# Altium schematic sheet Supply.SchDoc
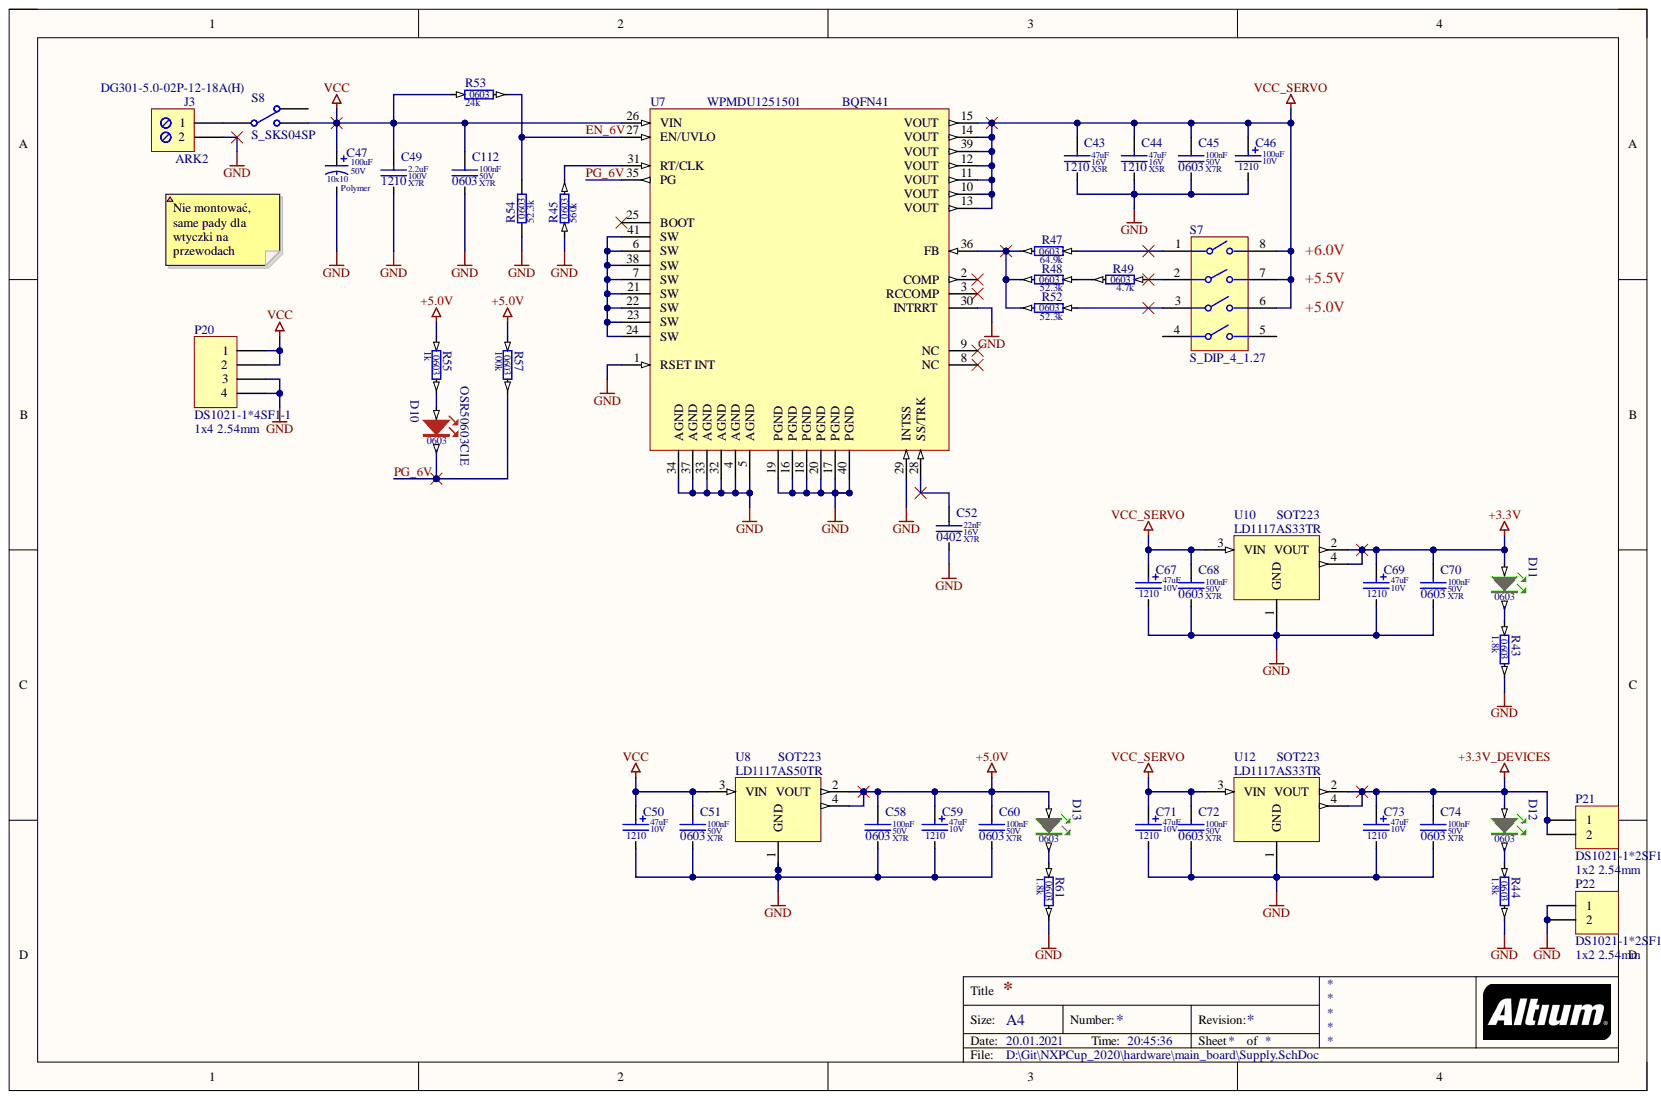
[diagram p0: Supply.SchDoc]

## Parts
C43  value 47uF  Dielectric: X5R  SCH_Footprint: 1210  Voltage: 16V  [C_1210_3225]  (hidden comment: Ceramic X5R)
C44  value 47uF  Dielectric: X5R  SCH_Footprint: 1210  Voltage: 16V  [C_1210_3225]  (hidden comment: Ceramic X5R)
C45  value 100nF  Dielectric: X7R  SCH_Footprint: 0603  Voltage: 50V  [C_0603_1608]  (hidden comment: Ceramic X7R)
C46  value 100uF  SCH_Footprint: 1210  Voltage: 10V  [CT_1210_3225]  (hidden comment: Tantalum Capacitor)
C47  value 100uF  Dielectric: Polymer  SCH_Footprint: 10x10  Voltage: 50V  [CE_D_10x10MM]  (hidden comment: Electrolytic Capacitor)
C49  value 2.2uF  Dielectric: X7R  SCH_Footprint: 1210  Voltage: 100V  [C_1210_3225]  (hidden comment: Ceramic X7R)
C50  value 47uF  SCH_Footprint: 1210  Voltage: 10V  [CT_1210_3225]  (hidden comment: Tantalum Capacitor)
C51  value 100nF  Dielectric: X7R  SCH_Footprint: 0603  Voltage: 50V  [C_0603_1608]  (hidden comment: Ceramic X7R)
C52  value 22nF  Dielectric: X7R  SCH_Footprint: 0402  Voltage: 16V  [C_0402_1005]  (hidden comment: Ceramic X7R)
C58  value 100nF  Dielectric: X7R  SCH_Footprint: 0603  Voltage: 50V  [C_0603_1608]  (hidden comment: Ceramic X7R)
C59  value 47uF  SCH_Footprint: 1210  Voltage: 10V  [CT_1210_3225]  (hidden comment: Tantalum Capacitor)
C60  value 100nF  Dielectric: X7R  SCH_Footprint: 0603  Voltage: 50V  [C_0603_1608]  (hidden comment: Ceramic X7R)
C67  value 47uF  SCH_Footprint: 1210  Voltage: 10V  [CT_1210_3225]  (hidden comment: Tantalum Capacitor)
C68  value 100nF  Dielectric: X7R  SCH_Footprint: 0603  Voltage: 50V  [C_0603_1608]  (hidden comment: Ceramic X7R)
C69  value 47uF  SCH_Footprint: 1210  Voltage: 10V  [CT_1210_3225]  (hidden comment: Tantalum Capacitor)
C70  value 100nF  Dielectric: X7R  SCH_Footprint: 0603  Voltage: 50V  [C_0603_1608]  (hidden comment: Ceramic X7R)
C71  value 47uF  SCH_Footprint: 1210  Voltage: 10V  [CT_1210_3225]  (hidden comment: Tantalum Capacitor)
C72  value 100nF  Dielectric: X7R  SCH_Footprint: 0603  Voltage: 50V  [C_0603_1608]  (hidden comment: Ceramic X7R)
C73  value 47uF  SCH_Footprint: 1210  Voltage: 10V  [CT_1210_3225]  (hidden comment: Tantalum Capacitor)
C74  value 100nF  Dielectric: X7R  SCH_Footprint: 0603  Voltage: 50V  [C_0603_1608]  (hidden comment: Ceramic X7R)
C112  value 100nF  Dielectric: X7R  SCH_Footprint: 0603  Voltage: 50V  [C_0603_1608]  (hidden comment: Ceramic X7R)
D10  value OSR50603C1E  Manufacturer Part Number: OSR50603C1E  SCH_Footprint: 0603  [OL_0603_1608]  (hidden comment: Red led)
D11  SCH_Footprint: 0603  [OL_0603_1608]  (hidden comment: OL_G)
D12  SCH_Footprint: 0603  [OL_0603_1608]  (hidden comment: OL_G)
D13  SCH_Footprint: 0603  [OL_0603_1608]  (hidden comment: OL_G)
J3  ARK2  value DG301-5.0-02P-12-18A(H)  Manufacturer Part Number: DG301-5.0-02P-12-18A(H)  [J_ARK2]
P20  value DS1021-1*4SF1-1  Manufacturer Part Number: DS1021-1*4SF1-1  SCH_Footprint: 1x4 2.54mm  [P_HEADER_1x4_2.54]  (hidden comment: Goldpin)
P21  Goldpin  [P_HEADER_1x2_2.54]  (value not drawn)
P22  SCH_Footprint: 1x2 2.54mm  [P_HEADER_1x2_2.54]  (hidden comment: Goldpin)
R43  value 1.8k  SCH_Footprint: 0603  [R_0603_1608]  (hidden comment: Resistor)
R44  value 1.8k  SCH_Footprint: 0603  [R_0603_1608]  (hidden comment: Resistor)
R45  value 560k  SCH_Footprint: 0603  [R_0603_1608]  (hidden comment: Resistor)
R47  value 64.9k  SCH_Footprint: 0603  [R_0603_1608]  (hidden comment: Resistor)
R48  value 52.3k  SCH_Footprint: 0603  [R_0603_1608]  (hidden comment: Resistor)
R49  value 4.7k  SCH_Footprint: 0603  [R_0603_1608]  (hidden comment: Resistor)
R52  value 52.3k  SCH_Footprint: 0603  [R_0603_1608]  (hidden comment: Resistor)
R53  value 24k  SCH_Footprint: 0603  [R_0603_1608]  (hidden comment: Resistor)
R54  value 52.3k  SCH_Footprint: 0603  [R_0603_1608]  (hidden comment: Resistor)
R55  value 1k  SCH_Footprint: 0603  [R_0603_1608]  (hidden comment: Resistor)
R57  value 100k  SCH_Footprint: 0603  [R_0603_1608]  (hidden comment: Resistor)
R61  value 1.8k  SCH_Footprint: 0603  [R_0603_1608]  (hidden comment: Resistor)
S7  DIP SWITCH 4 POS  [S_DIP_4_1.27]  (value not drawn)
S8  S_SKS04SP  [Switch_toggle]
U7  WPMDU1251501  SCH_Footprint: BQFN41  [BQFN41_11x9]
U8  LD1117AS50TR  SCH_Footprint: SOT223  [SOT223_SC73]
U10  LD1117AS33TR  SCH_Footprint: SOT223  [SOT223_SC73]
U12  LD1117AS33TR  SCH_Footprint: SOT223  [SOT223_SC73]

## Nets
+3.3V: C69.1 C70.1 D11.2 U10.2(VOUT) U10.4
+3.3V_DEVICES: C73.1 C74.1 D12.2 P21.1 P21.2 U12.2(VOUT) U12.4
+5.0V: C58.1 C59.1 C60.1 D13.2 R55.1 R57.1 U8.2(VOUT) U8.4
GND: C43.2 C44.2 C45.2 C46.2 C47.2 C49.2 C50.2 C51.2 C52.2 C58.2 C59.2 C60.2 C67.2 C68.2 C69.2 C70.2 C71.2 C72.2 C73.2 C74.2 C112.2 J3.2 P20.3 P20.4 P22.1 P22.2 R43.2 R44.2 R45.1 R54.1 R61.2 U7.1(RSET_INT) U7.4(AGND) U7.5(AGND) U7.16(PGND) U7.17(PGND) U7.18(PGND) U7.19(PGND) U7.20(PGND) U7.29(INTSS) U7.30(INTRRT) U7.32(AGND) U7.33(AGND) U7.34(AGND) U7.37(AGND) U7.40(PGND) U8.1(GND) U10.1(GND) U12.1(GND)
VCC: C47.1 C49.1 C50.1 C51.1 C112.1 P20.1 P20.2 R53.1 S8.3 U7.26(VIN) U8.3(VIN)
VCC_SERVO: C43.1 C44.1 C45.1 C46.1 C67.1 C68.1 C71.1 C72.1 S7.6 S7.7 S7.8 U7.10(VOUT) U7.11(VOUT) U7.12(VOUT) U7.13(VOUT) U7.14(VOUT) U7.15(VOUT) U7.39(VOUT) U10.3(VIN) U12.3(VIN)
EN_6V: R53.2 R54.2 U7.27(EN/UVLO)
PG_6V: D10.1 R57.2 U7.35(PG)
NetC52_1: C52.1 U7.28(SS/TRK)
NetD10_2: D10.2 R55.2
NetD11_1: D11.1 R43.1
NetD12_1: D12.1 R44.1
NetD13_1: D13.1 R61.1
NetJ3_1: J3.1 S8.2
NetR45_2: R45.2 U7.31(RT/CLK)
NetR47_1: R47.1 S7.1
NetR47_2: R47.2 R48.2 R52.2 U7.36(FB)
NetR48_1: R48.1 R49.2
NetR49_1: R49.1 S7.2
NetR52_1: R52.1 S7.3
NetU7_6: U7.6(SW) U7.7(SW) U7.21(SW) U7.22(SW) U7.23(SW) U7.24(SW) U7.38(SW) U7.41(SW)

Not connected: S7 4 5; S8 1; U7 2(COMP) 3(RCCOMP) 8(NC) 9(NC) 25(BOOT)

## Library data of the parts
C43 C44 = C_47uF_16V_X5R_1210_10: Capacitor; Manufacturer: Samsung; Manufacturer Part Number: CL32A476KOJNNNE; Database Table Name: Capacitors; Tolerance (%): 10; Component Kind: Standard; HelpURL: http://www.samsungsem.com/kr/support/product-search/mlcc/__icsFiles/afieldfile/2018/06/21/CL32A476KOJNNNE.pdf; Library Name: Library.DBLib; Part Number: C_47uF_16V_X5R_1210_10; Supplier 1: TME; Supplier 2: RS Components; Supplier 3: Farnell; Supplier 4: Mouser; Supplier 5: Digi-Key; Supplier Part Number 5: 1276-3376-1-ND
C45 C51 C58 C60 C68 C70 C72 C74 C112 = C_100nF_50V_X7R_0603_5: Capacitor; Manufacturer: Samsung; Manufacturer Part Number: CL10B104JB8NNNC; Database Table Name: Capacitors; Tolerance (%): 5; Component Kind: Standard; HelpURL: http://www.tme.eu/pl/Document/4a42202b32dab16128fe107dd69598cc/samsung-chip-cap.pdf; Library Name: Library.DBLib; Part Number: C_100nF_50V_X7R_0603_5; Supplier 1: TME; Supplier 2: RS Components; Supplier 3: Farnell; Supplier 4: Mouser; Supplier 5: Digi-Key; Supplier Part Number 1: CL10B104JB8NNNC
C46 C50 C59 C67 C69 C71 C73 = CT_100uF_10V_TA2O5_1210_20: Capacitor; Manufacturer: AVX; Manufacturer Part Number: TAJB107M010RNJ; Database Table Name: Capacitors; Dielectric: TA2O5; Tolerance (%): 20; Component Kind: Standard; HelpURL: https://datasheet.octopart.com/TAJB107M010RNJ-AVX-datasheet-12511273.pdf; Library Name: Library.DBLib; Part Number: CT_100uF_10V_TA2O5_1210_20; Supplier 1: TME; Supplier 2: RS Components; Supplier 3: Farnell; Supplier 4: Mouser; Supplier 5: Digi-Key; Supplier Part Number 1: TAJB107M010R; Supplier Part Number 2: 1360118; Supplier Part Number 3: 1658150; Supplier Part Number 4: 581-TAJB107M010R; Supplier Part Number 5: 478-5801-1-ND
C47 = CE_100uF_50V_Polymer_10x10_20: Polarized Capacitor; Manufacturer: Nichicon; Manufacturer Part Number: UUX1H101MNL1GS; Database Table Name: Capacitors; Tolerance (%): 20; Component Kind: Standard; HelpURL: https://datasheet.octopart.com/UUX1H101MNL1GS-Nichicon-datasheet-62047998.pdf; Library Name: Library.DBLib; Part Number: CE_100uF_50V_Polymer_10x10_20; Supplier 1: TME; Supplier 2: RS Components; Supplier 3: Farnell; Supplier 4: Mouser; Supplier 5: Digi-Key; Supplier Part Number 1: UUX1H101MNL1GS; Supplier Part Number 2: 739-3107; Supplier Part Number 3: 2841943; Supplier Part Number 4: 647-UUX1H101MNL1GS; Supplier Part Number 5: 493-6269-1-ND
C49 = C_2.2uF_100V_X7R_1210_10: Capacitor; Manufacturer: Samsung; Manufacturer Part Number: CL32B225KCJSNNE; Database Table Name: Capacitors; Tolerance (%): 10; Component Kind: Standard; HelpURL: http://datasheet.octopart.com/CL32B225KCJSNNE-Samsung-datasheet-37081328.pdf; Library Name: Library.DBLib; Part Number: C_2.2uF_100V_X7R_1210_10; Supplier 1: TME; Supplier 2: RS Components; Supplier 3: Farnell; Supplier 4: Mouser; Supplier 5: Digi-Key; Supplier Part Number 1: CL32B225KCJSNNE; Supplier Part Number 2: 7661211P; Supplier Part Number 5: 1276-3362-1-ND
C52 = C_22nF_16V_X7R_0402_10: Capacitor; Manufacturer: AVX; Manufacturer Part Number: 0402YC223KAT2A; Database Table Name: Capacitors; Tolerance (%): 10; Component Kind: Standard; HelpURL: http://datasheet.octopart.com/0402YC223KAT2A-AVX-datasheet-78690206.pdf; Library Name: Library.DBLib; Part Number: C_22nF_16V_X7R_0402_10; Supplier 1: TME; Supplier 2: RS Components; Supplier 3: Farnell; Supplier 4: Mouser; Supplier 5: Digi-Key; Supplier Part Number 1: 0402YC223KAT2A; Supplier Part Number 2: 6983188; Supplier Part Number 3: 2280808; Supplier Part Number 4: 581-0402YC223K; Supplier Part Number 5: 478-1118-1-ND
D10 = OL_R_0603_OSR50603C1E: LED_RED; Manufacturer: Optosupply; Database Table Name: Optoelectronics; Component Kind: Standard; Library Name: Library.DBLib; Part Number: OL_R_0603_OSR50603C1E; Supplier 1: TME; Supplier 2: RS Components; Supplier 3: Farnell; Supplier 4: Mouser; Supplier 5: Digi-Key; Supplier Part Number 1: OSR50603C1E
D11-D13 = OL_G_0603_0C06_APG1608CGKC/T: LED GREEN; Database Table Name: Optoelectronics; Component Kind: Standard; Library Name: Library.DBLib; Part Number: OL_G_0603_0C06_APG1608CGKC/T; Supplier 1: TME; Supplier 2: RS Components; Supplier 3: Farnell; Supplier 4: Mouser; Supplier 5: Digi-Key; Supplier Part Number 5: 754-1357-1-ND
J3 = J_TB-5.0-P-2P: ARK Screw terminal, 2-Pin; Manufacturer: DEGSON ELECTRONICS; Database Table Name: Connectors; Component Kind: Standard; Component Type: Standard; HelpURL: https://datasheet.octopart.com/DG301-5.0-03P-12-18A%28H%29-Degson-datasheet-17801202.pdf; Library Name: Library.DBLib; Part Number: J_TB-5.0-P-2P; SCH_Footprint: ARK2; Supplier 1: TME; Supplier 2: RS Components; Supplier 3: Farnell; Supplier 4: Mouser; Supplier 5: Digi-Key; Supplier Part Number 1: DG301-5.0-2/6B
P20 = P_HEADER_1x4_2.54: Header, 4-Pin; Manufacturer: Connfly; Database Table Name: Connectors; Component Kind: Standard; Component Type: Standard; HelpURL: http://datasheet.octopart.com/DS1021-1%2A4SF1-1-Connfly-datasheet-65537859.pdf; Library Name: Library.DBLib; Part Number: P_HEADER_1x4_2.54; Supplier 1: TME; Supplier 2: RS Components; Supplier 3: Farnell; Supplier 4: Mouser; Supplier 5: Digi-Key; Supplier Part Number 1: ZL201-04G
P21 = P_HEADER_1x2_2.54: Header, 2-Pin; Manufacturer: Connfly; Manufacturer Part Number: DS1021-1*2SF1-1; Database Table Name: Connectors; SCH_Footprint: 1x2 2.54mm; Value: DS1021-1*2SF1-1; Component Kind: Standard; Component Type: Standard; HelpURL: http://www.tme.eu/en/Document/cc301e58a5da78cb543a94b5a5b7f06a/DS1021.pdf; Library Name: Library.DBLib; Part Number: P_HEADER_1x2_2.54; Supplier 1: TME; Supplier 2: RS Components; Supplier 3: Farnell; Supplier 4: Mouser; Supplier 5: Digi-Key; Supplier Part Number 1: ZL201-02G
P22 = P_HEADER_1x2_2.54: Header, 2-Pin; Manufacturer: Connfly; Manufacturer Part Number: DS1021-1*2SF1-1; Database Table Name: Connectors; Value: DS1021-1*2SF1-1; Component Kind: Standard; Component Type: Standard; HelpURL: http://www.tme.eu/en/Document/cc301e58a5da78cb543a94b5a5b7f06a/DS1021.pdf; Library Name: Library.DBLib; Part Number: P_HEADER_1x2_2.54; Supplier 1: TME; Supplier 2: RS Components; Supplier 3: Farnell; Supplier 4: Mouser; Supplier 5: Digi-Key; Supplier Part Number 1: ZL201-02G
R43 R44 R61 = R_1.8k_0603_1: Manufacturer: Vishay; Manufacturer Part Number: CRCW06031K80FKTABC; Database Table Name: Resistors; Power: 100mW; Tolerance: 1%; Voltage: 75V; Component Kind: Standard; HelpURL: https://www.tme.eu/pl/Document/622e28308c06d160637f2b14751ba16b/Data%20Sheet%20CRCW_BCe3.pdf; Library Name: Library.DBLib; Part Number: R_1.8k_0603_1; Supplier 1: TME; Supplier 2: RS Components; Supplier 3: Farnell; Supplier 4: Mouser; Supplier 5: Digi-Key; Supplier Part Number 1: CRCW06031K80FKTABC
R45 = R_560k_0603_0.1: Manufacturer: TE Connectivity; Manufacturer Part Number: CPF0603B560KE1; Database Table Name: Resistors; Power: 100mW; Tolerance: 0.1%; Voltage: 50V; Component Kind: Standard; HelpURL: https://datasheet.octopart.com/CPF0603B560KE1-Tyco-Electronics-datasheet-11120060.pdf; Library Name: Library.DBLib; Part Number: R_560k_0603_0.1; Supplier 1: TME; Supplier 2: RS Components; Supplier 3: Farnell; Supplier 4: Mouser; Supplier 5: Digi-Key; Supplier Part Number 3: 2331278; Supplier Part Number 4: 279-CPF0603B560KE1; Supplier Part Number 5: A102294CT-ND
R47 = R_64.9k_0603_1: Manufacturer: Panasonic; Manufacturer Part Number: ERJ-3EKF6492V; Database Table Name: Resistors; Power: 100mW; Tolerance: 1%; Voltage: 75V; Component Kind: Standard; HelpURL: https://industrial.panasonic.com/cdbs/www-data/pdf/RDA0000/AOA0000C304.pdf; Library Name: Library.DBLib; Part Number: R_64.9k_0603_1; Supplier 1: TME; Supplier 2: RS Components; Supplier 3: Farnell; Supplier 4: Mouser; Supplier 5: Digi-Key; Supplier Part Number 2: 1229382; Supplier Part Number 3: 2059481; Supplier Part Number 4: 667-ERJ-3EKF6492V; Supplier Part Number 5: P64.9KHCT-ND
R48 R52 R54 = R_52.3k_0603_1: Manufacturer: Yageo; Manufacturer Part Number: RC0603FR-0752K3L; Database Table Name: Resistors; Power: 100mW; Tolerance: 1%; Voltage: 75V; Component Kind: Standard; HelpURL: http://www.yageo.com/documents/recent/PYu-RC_Group_51_RoHS_L_9.pdf; Library Name: Library.DBLib; Part Number: R_52.3k_0603_1; Supplier 1: TME; Supplier 2: RS Components; Supplier 3: Farnell; Supplier 4: Mouser; Supplier 5: Digi-Key; Supplier Part Number 4: 603-RC0603FR-0752K3L; Supplier Part Number 5: 311-52.3KHRDKR-ND
R49 = R_4.7k_0603_1: Manufacturer: Yageo; Manufacturer Part Number: RC0603FR-074K7L; Database Table Name: Resistors; Power: 100mW; Tolerance: 1%; Voltage: 75V; Component Kind: Standard; HelpURL: http://www.farnell.com/datasheets/2167052.pdf?_ga=2.156163131.1690599162.1548417389-1379097396.1542296996&_gac=1.18389067.1547230258.Cj0KCQiAmuHhBRD0ARIsAFWyPwhX8HcvXnM3tA0ZBodZLzDIjH2ZxLpjT5LWo9bad_HPRbU8LfPq44UaAhEwEALw_wcB; Library Name: Library.DBLib; Part Number: R_4.7k_0603_1; Supplier 1: TME; Supplier 2: RS Components; Supplier 3: Farnell; Supplier 4: Mouser; Supplier 5: Digi-Key; Supplier Part Number 1: RC0603FR-074K7; Supplier Part Number 3: 9238565; Supplier Part Number 4: 603-RC0603FR-074K7L; Supplier Part Number 5: 311-4.70KHRCT-ND
R53 = R_24k_0603_1: Manufacturer: Vishay; Manufacturer Part Number: CRCW060324K0FKTABC; Database Table Name: Resistors; Power: 100mW; Tolerance: 1%; Voltage: 75V; Component Kind: Standard; HelpURL: http://www.tme.eu/pl/Document/622e28308c06d160637f2b14751ba16b/Data%20Sheet%20CRCW_BCe3.pdf; Library Name: Library.DBLib; Part Number: R_24k_0603_1; Supplier 1: TME; Supplier 2: RS Components; Supplier 3: Farnell; Supplier 4: Mouser; Supplier 5: Digi-Key; Supplier Part Number 1: CRCW060324K0FKTABC
R55 = R_1k_0603_1: Manufacturer: Vishay; Manufacturer Part Number: CRCW06031K00FKEA; Database Table Name: Resistors; Power: 100mW; Tolerance: 1%; Voltage: 75V; Component Kind: Standard; HelpURL: http://www.farnell.com/datasheets/2310790.pdf?_ga=2.256888843.1690599162.1548417389-1379097396.1542296996&_gac=1.262631934.1547230258.Cj0KCQiAmuHhBRD0ARIsAFWyPwhX8HcvXnM3tA0ZBodZLzDIjH2ZxLpjT5LWo9bad_HPRbU8LfPq44UaAhEwEALw_wcB; Library Name: Library.DBLib; Part Number: R_1k_0603_1; Supplier 1: TME; Supplier 2: RS Components; Supplier 3: Farnell; Supplier 4: Mouser; Supplier 5: Digi-Key; Supplier Part Number 2: 678-9875P; Supplier Part Number 3: 1469740; Supplier Part Number 4: 71-CRCW0603-1.0K-E3; Supplier Part Number 5: 541-1.00KHCT-ND
R57 = R_100k_0603_1: Manufacturer: Royalohm; Manufacturer Part Number: 0603SAF1003T5E; Database Table Name: Resistors; Power: 100mW; Tolerance: 1%; Voltage: 50V; Component Kind: Standard; HelpURL: http://www.tme.eu/pl/Document/b315665a56acbc42df513c99b390ad98/ROYALOHM-THICKFILM.pdf; Library Name: Library.DBLib; Part Number: R_100k_0603_1; Supplier 1: TME; Supplier 2: RS Components; Supplier 3: Farnell; Supplier 4: Mouser; Supplier 5: Digi-Key; Supplier Part Number 1: SMD0603-100K-1%; Supplier Part Number 4: 667-ERJ-3EKF1003V; Supplier Part Number 5: P100KHCT-ND
S7 = S_A6H-4101: Manufacturer: OMRON; Database Table Name: Switches; Component Kind: Standard; HelpURL: http://www.farnell.com/datasheets/2085437.pdf?_ga=2.8950133.1711911076.1544805648-547095826.1543447744; Library Name: Library.DBLib; Manufacturer Part Number: A6H-4101; Part Number: S_A6H-4101; SCH_Footprint: S_DIP_4_1.27; Supplier 1: TME; Supplier 2: RS Components; Supplier 3: Farnell; Supplier 4: Mouser; Supplier 5: Digi-Key; Supplier Part Number 1: A6H-4101; Supplier Part Number 2: 6866890; Supplier Part Number 3: 8774269; Supplier Part Number 4: 653-A6H-4101; Supplier Part Number 5: SW1080-ND
U7 = VS_WPMDU1251501: Manufacturer: Wurth; Database Table Name: Switching regulators; Frequency (kHz): 1000; Imax (A): 2,5; Iswitch (A): 0; Topology: Buck; Vin max (V): 50; Vin min (V): 7; Vout max (V): 15; Component Kind: Standard; Description: WPMDU1251501; HelpURL: https://katalog.we-online.de/pm/datasheet/171021501.pdf; Library Name: Library.DBLib; Manufacturer Part Number: WPMDU1251501; Part Number: VS_WPMDU1251501; Supplier 1: TME; Supplier 2: RS Components; Supplier 3: Farnell; Supplier 4: Mouser; Supplier 5: Digi-Key; Supplier Part Number 5: 732-6217-1-ND; Value: WPMDU1251501; Vout min (V): 2,5
U8 U10 U12 = VL_LD1117AS33TR_3V3_1A2: Low-Dropout Positive Voltage Regulator; Manufacturer: STMicroelectronics; Current: 1A2; Database Table Name: Voltage Regulators; Voltage: 3.3V; Component Kind: Standard; HelpURL: http://www.tme.eu/pl/Document/bad00e7fc591b73ff810af309b2bb7a4/LD1117A.pdf; Library Name: Library.DBLib; Part Number: VL_LD1117AS33TR_3V3_1A2; Supplier 1: TME; Supplier 2: RS Components; Supplier 3: Farnell; Supplier 4: Mouser; Supplier 5: Digi-Key; Supplier Part Number 1: LD1117AS33; Supplier Part Number 3: 1467776; Supplier Part Number 4: LD1117AS33; Supplier Part Number 5: 497-1228-1-ND
  U8: Manufacturer Part Number: LD1117AS50TR; Value: LD1117AS50TR
  U10 U12: Manufacturer Part Number: LD1117AS33TR; Value: LD1117AS33TR
S8 = S_SKS04SP: Component Kind: Standard; Library Name: Custom.IntLib
